# Altium schematic sheet PM3_Bosclone_ANALOG_TX_4.SchDoc(PM3_Bosclone_ANALOG_TX_4)
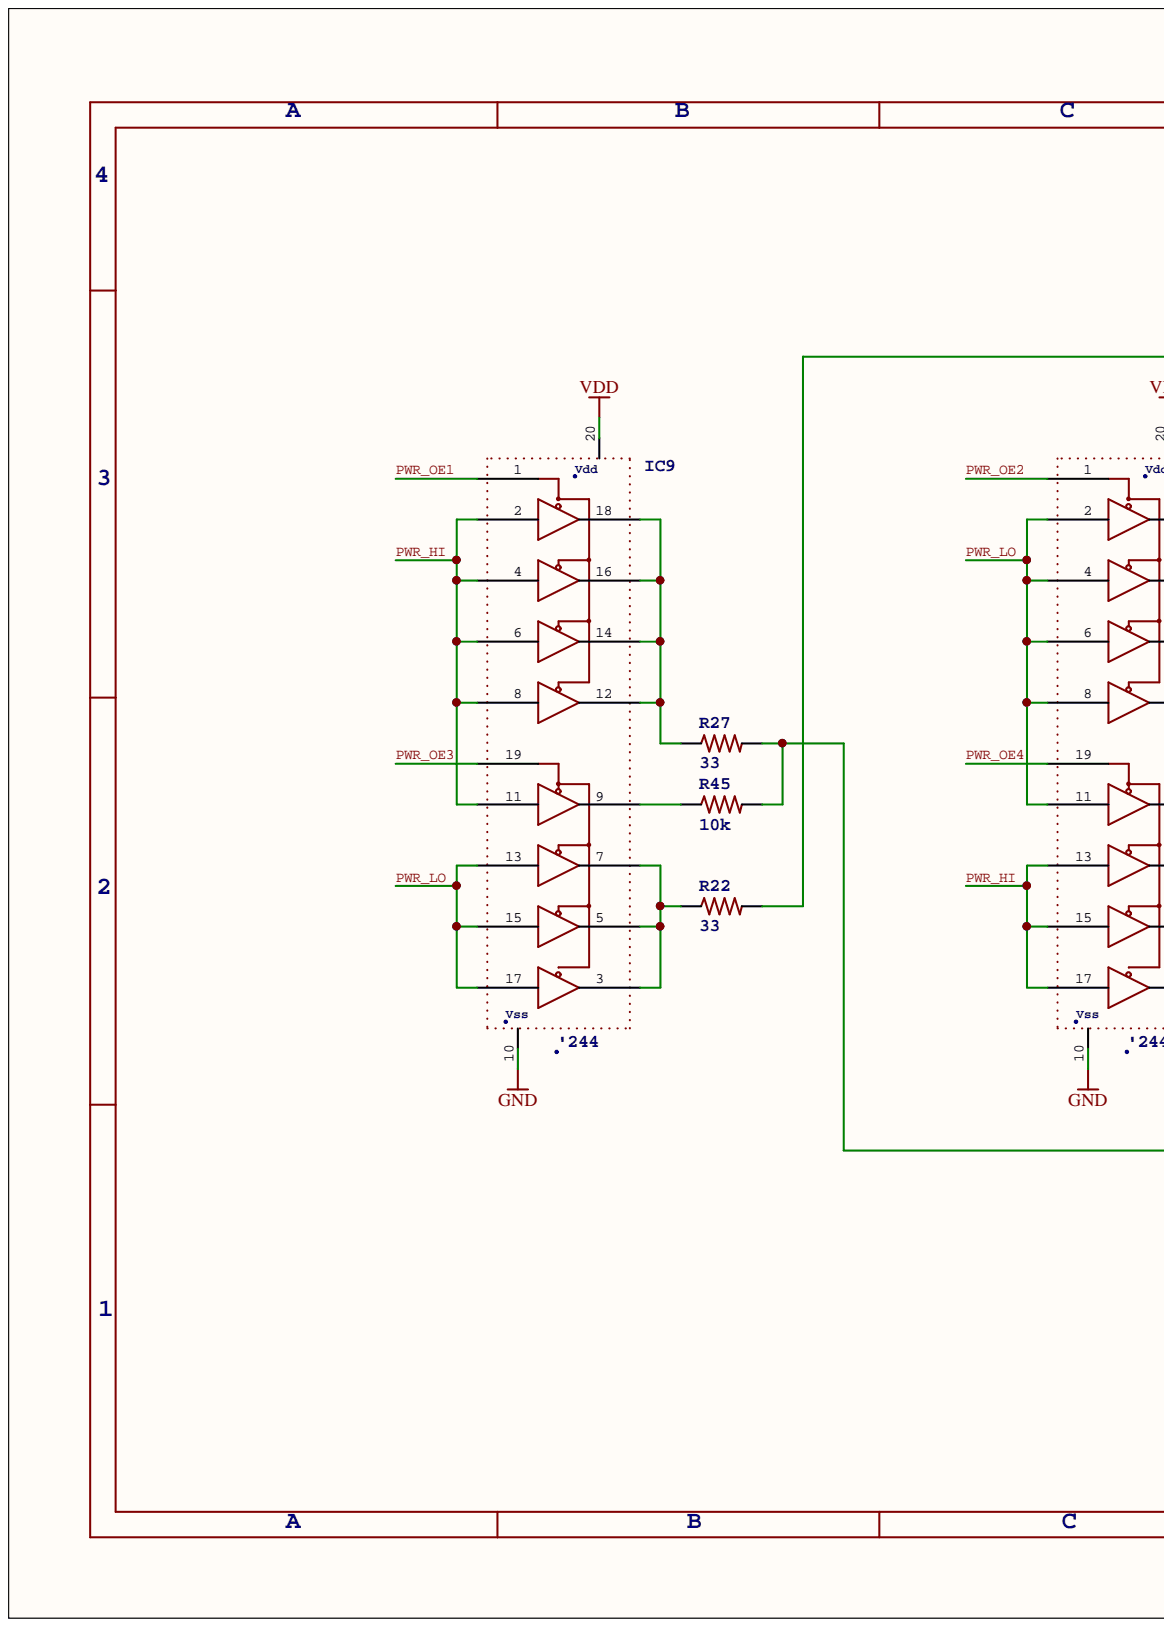
[diagram p0: PM3_Bosclone_ANALOG_TX_4.SchDoc, left]
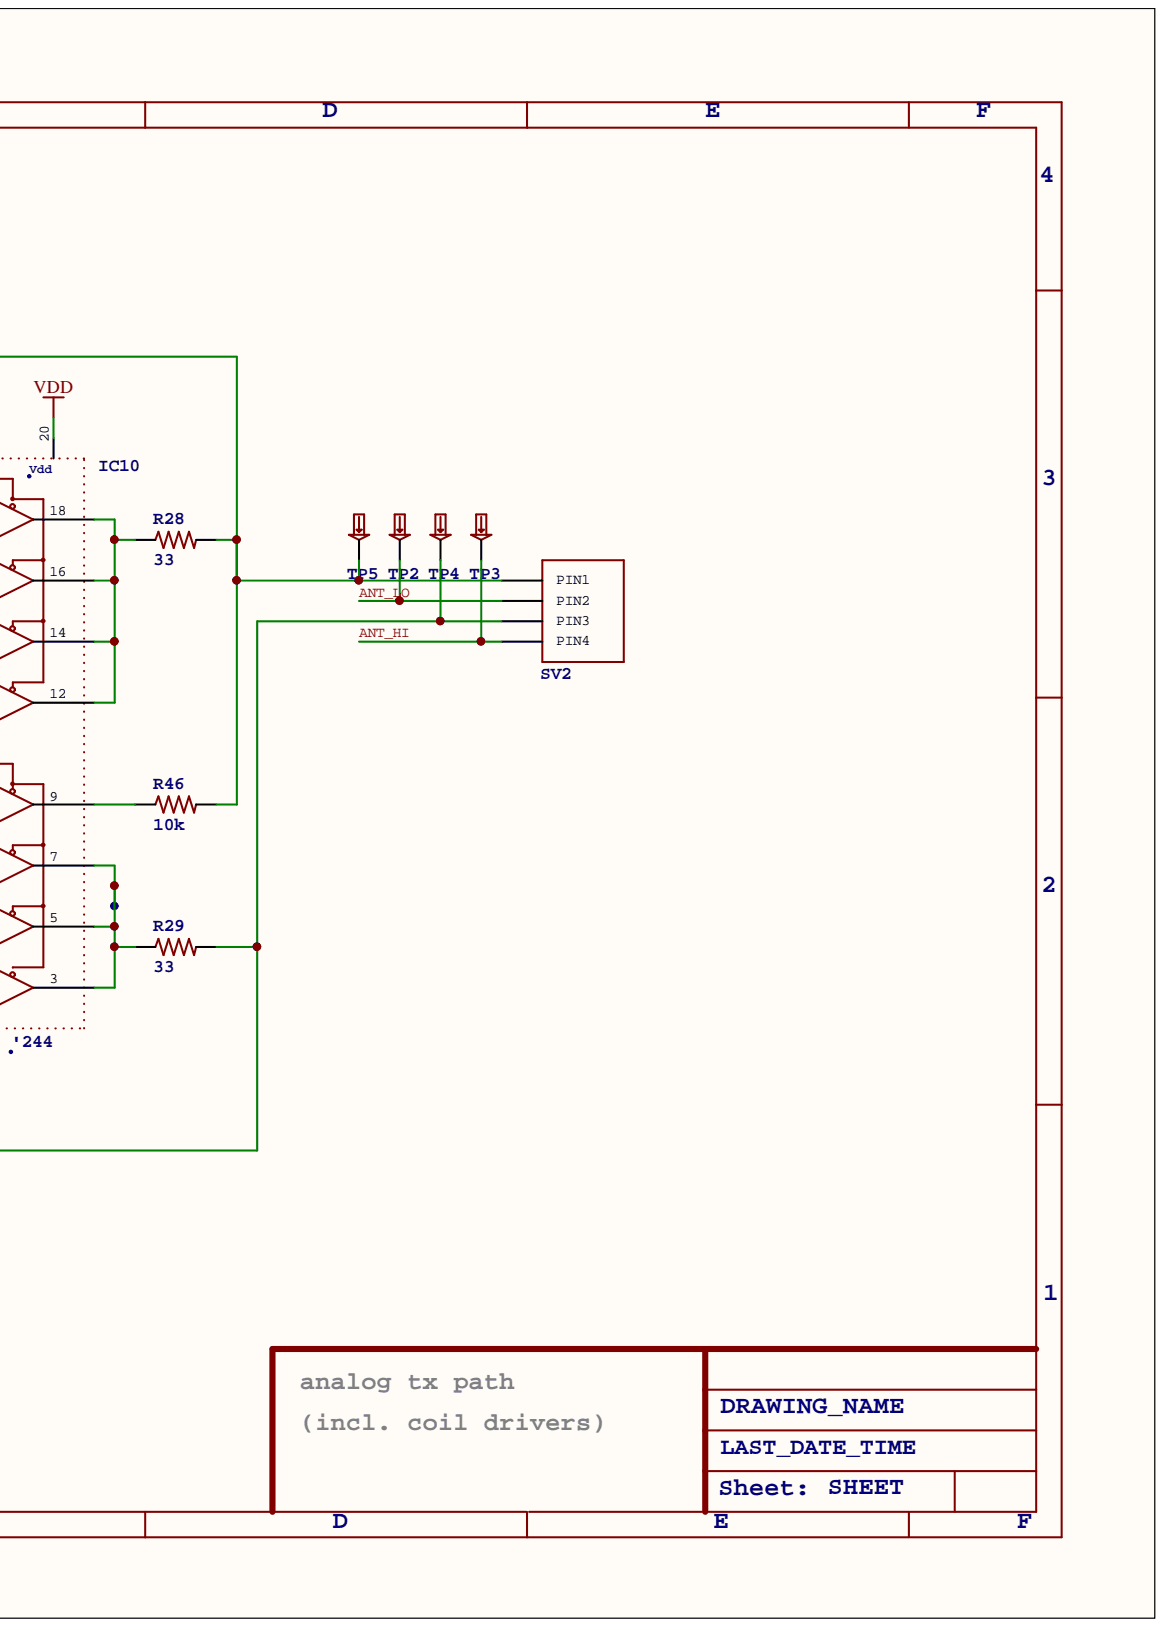
[diagram p1: PM3_Bosclone_ANALOG_TX_4.SchDoc, right]

## Parts
IC9  74XX244-OCTAL-TRISTATE-BUFFERS  [TSSOP-20-extended]  (value not drawn)
IC10  74XX244-OCTAL-TRISTATE-BUFFERS  [TSSOP-20-extended]  (value not drawn)
R22  33  [RLC_0603]
R27  33  [RLC_0603]
R28  33  [RLC_0603]
R29  33  [RLC_0603]
R45  10k  [RLC_0603]
R46  10k  [RLC_0603]
SV2  HIROSE-MQ172-4POS  [HIROSE-MQ172-4POS]  (value not drawn)
TP2  TESTPADKEYSTONE-SMD  [KEYSTONE-SMD-TESTPOINT-5015]  (value not drawn)
TP3  TESTPADKEYSTONE-SMD  [KEYSTONE-SMD-TESTPOINT-5015]  (value not drawn)
TP4  TESTPADKEYSTONE-SMD  [KEYSTONE-SMD-TESTPOINT-5015]  (value not drawn)
TP5  TESTPADKEYSTONE-SMD  [KEYSTONE-SMD-TESTPOINT-5015]  (value not drawn)

## Nets
GND: IC9.10 IC10.10
VDD: IC9.20 IC10.20
ANT_HI: SV2.4(PIN4) TP3.1
ANT_LO: SV2.2(PIN2) TP2.1
PWR_HI: IC9.2 IC9.4 IC9.6 IC9.8 IC9.11 IC10.13 IC10.15 IC10.17
PWR_LO: IC9.13 IC9.15 IC9.17 IC10.2 IC10.4 IC10.6 IC10.8 IC10.11
PWR_OE1: IC9.1
PWR_OE2: IC10.1
PWR_OE3: IC9.19
PWR_OE4: IC10.19
NetIC9_3: IC9.3 IC9.5 IC9.7 R22.1
NetIC9_9: IC9.9 R45.1
NetIC9_12: IC9.12 IC9.14 IC9.16 IC9.18 R27.1
NetIC10_3: IC10.3 IC10.5 IC10.7 R29.1
NetIC10_9: IC10.9 R46.1
NetIC10_12: IC10.12 IC10.14 IC10.16 IC10.18 R28.1
NetR22_2: R22.2 R28.2 R46.2 SV2.1(PIN1) TP5.1
NetR27_2: R27.2 R29.2 R45.2 SV2.3(PIN3) TP4.1

## Library data of the parts
R22 R27-R29 R45 R46 = RESISTOR0603: Component Kind: Standard; Library Name: merged.IntLib
IC9 IC10 = 74XX244-OCTAL-TRISTATE-BUFFERS: '244: '244; Component Kind: Standard; Vdd: Vdd; Vss: Vss; Library Name: merged.IntLib
SV2 = HIROSE-MQ172-4POS: Component Kind: Standard; Library Name: merged.IntLib
TP2-TP5 = TESTPADKEYSTONE-SMD: Component Kind: Standard; Library Name: merged.IntLib
